# Altium schematic sheet nrf52833_qdaa_var5.SchDoc
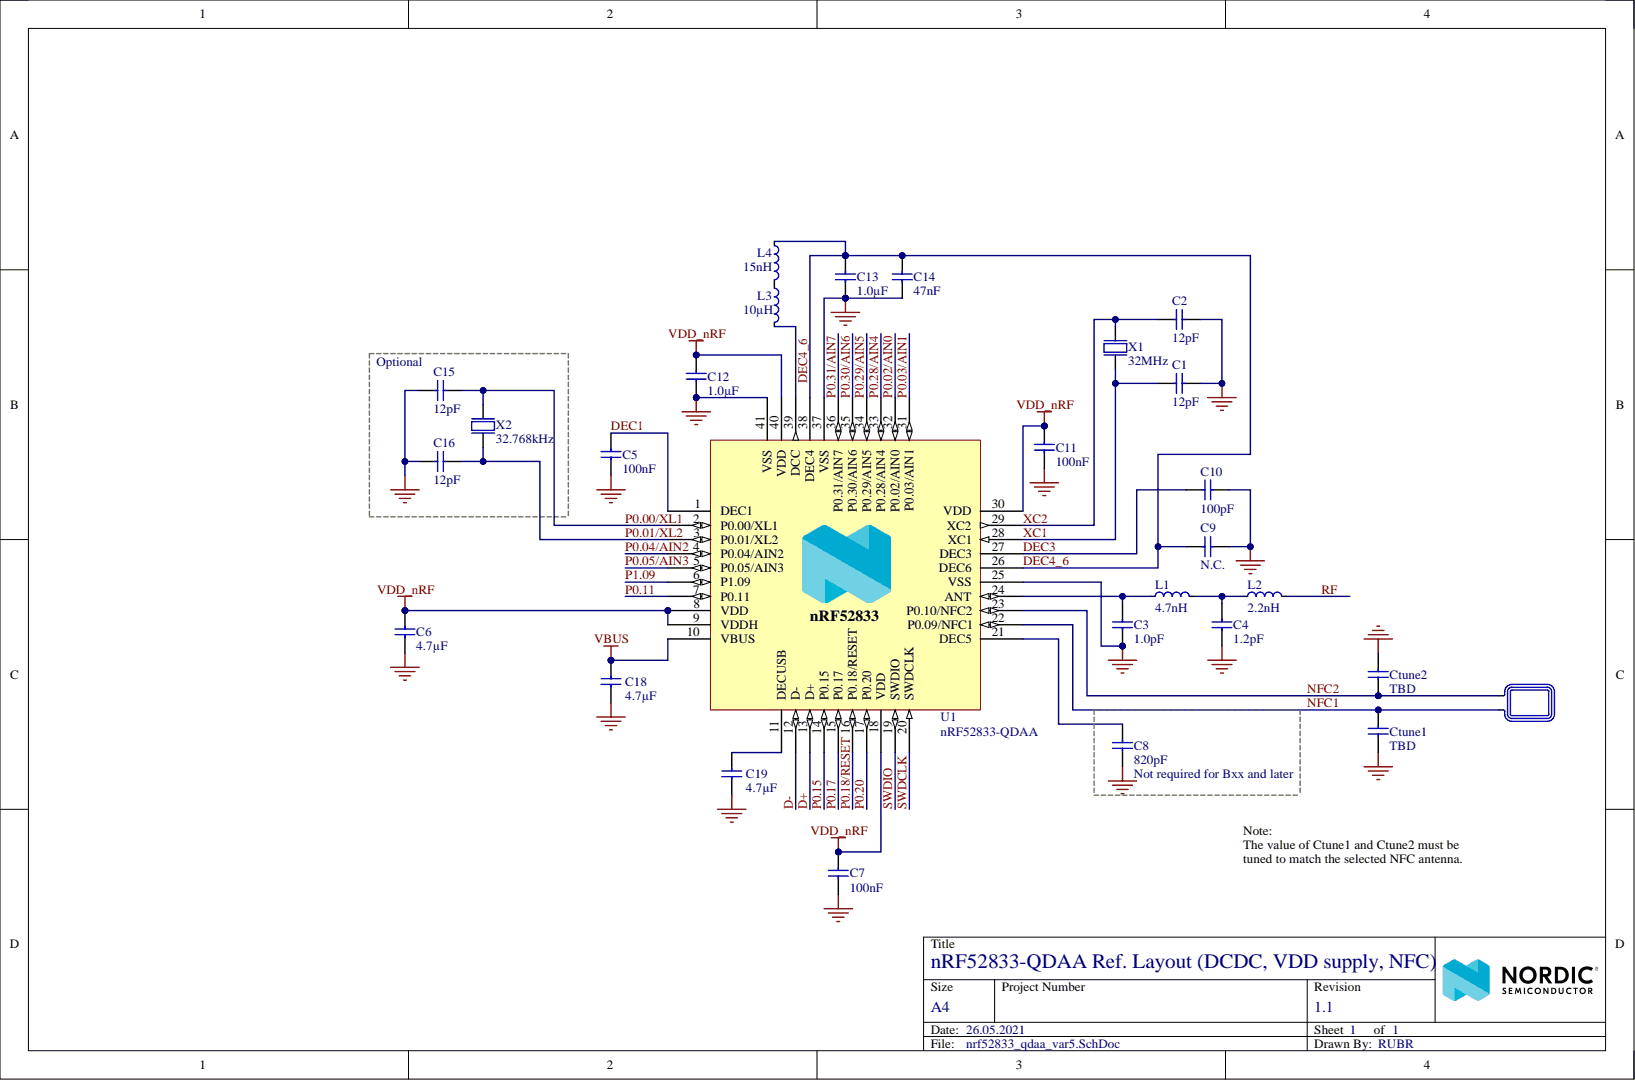
[diagram p0: nrf52833_qdaa_var5.SchDoc]

## Parts
A1  NFC Antenna  [TP_2x1mm_TH]  (value not drawn)  (no BOM)
C1  12pF  [CAPC0603X03L_C]
C2  12pF  [CAPC0603X03L_C]
C3  1.0pF  [CAPC0603X03L_C]
C4  1.2pF  [CAPC0603X03L_C]
C5  100nF  [CAPC0603X03L_C]
C6  4.7µF  [CAPC1608X06L]
C7  100nF  [CAPC0603X03L_C]
C8  820pF  [CAPC0603X03L_C]
C9  N.C.  [CAPC0603X03L_C]
C10  100pF  [CAPC0603X03L_C]
C11  100nF  [CAPC0603X03L_C]
C12  1.0µF  [CAPC1005X04L]
C13  1.0µF  [CAPC1005X04L]
C14  47nF  [CAPC0603X03L_C]
C15  12pF  [CAPC0603X03L_C]
C16  12pF  [CAPC0603X03L_C]
C18  4.7µF  [CAPC1608X06L]
C19  4.7µF  [CAPC1608X06L]
Ctune1  TBD  [CAPC0603X03L_C]
Ctune2  TBD  [CAPC0603X03L_C]
L1  4.7nH  [INDC0603X03L_C]
L2  2.2nH  [INDC0603X03L_C]
L3  10µH  [INDC1608X06L]
L4  15nH  [INDC1005X04L]
U1  nRF52833-QDAA  [QFN40P500X500X50_HS-41N]
X1  32MHz  [BT-XTAL_1612]
X2  32.768kHz  [XTAL_2012]

## Nets
GND: C1.2 C2.2 C3.1 C4.1 C5.2 C6.2 C7.2 C8.2 C9.1 C10.2 C11.2 C12.2 C13.1 C14.2 C15.2 C16.2 C18.2 C19.2 Ctune1.2 Ctune2.1 U1.25(VSS) U1.37(VSS) U1.41(VSS) X1.2 X1.4
VBUS: C18.1 U1.10(VBUS)
VDD_nRF: C6.1 C7.1 C11.1 C12.1 U1.8(VDD) U1.9(VDDH) U1.18(VDD) U1.30(VDD) U1.40(VDD)
D+: U1.13(D+)
D-: U1.12(D-)
DEC1: C5.1 U1.1(DEC1)
DEC3: C10.1 U1.27(DEC3)
DEC4_6: C9.2 C13.2 C14.1 L4.1 U1.26(DEC6) U1.38(DEC4)
NFC1: A1.2 Ctune1.1 U1.22(P0.09/NFC1)
NFC2: A1.1 Ctune2.2 U1.23(P0.10/NFC2)
P0.00/XL1: C15.1 U1.2(P0.00/XL1) X2.1
P0.01/XL2: C16.1 U1.3(P0.01/XL2) X2.2
P0.02/AIN0: U1.32(P0.02/AIN0)
P0.03/AIN1: U1.31(P0.03/AIN1)
P0.04/AIN2: U1.4(P0.04/AIN2)
P0.05/AIN3: U1.5(P0.05/AIN3)
P0.11: U1.7(P0.11)
P0.15: U1.14(P0.15)
P0.17: U1.15(P0.17)
P0.18/RESET: U1.16(P0.18/RESET)
P0.20: U1.17(P0.20)
P0.28/AIN4: U1.33(P0.28/AIN4)
P0.29/AIN5: U1.34(P0.29/AIN5)
P0.30/AIN6: U1.35(P0.30/AIN6)
P0.31/AIN7: U1.36(P0.31/AIN7)
P1.09: U1.6(P1.09)
RF: L2.2
SWDCLK: U1.20(SWDCLK)
SWDIO: U1.19(SWDIO)
XC1: C1.1 U1.28(XC1) X1.1
XC2: C2.1 U1.29(XC2) X1.3
NetC3_2: C3.2 L1.1 U1.24(ANT)
NetC4_2: C4.2 L1.2 L2.1
NetC8_1: C8.1 U1.21(DEC5)
NetC19_1: C19.1 U1.11(DECUSB)
NetL3_1: L3.1 L4.2
NetL3_2: L3.2 U1.39(DCC)

## Library data of the parts
A1 = NFC_ANT_TP: Database Table Name: Antennas$; Description: NFC Antenna; Footprint Doc: TP_2x1mm_TH; LatestRevisionDate: 2016-02-23; Library Name: Nordic Passive DB.DbLib; Part Number: NFC_ANT_TP; Pin Count: 2; Published: 2016-02-23; Value: NFC Antenna; Publisher: Nordic Semiconductor
C1 C2 C15 C16 = C0201-12p: Capacitor, NP0, ±2%; Database Table Name: Capacitors$; Component Kind: Standard; Footprint Doc: 0201; LatestRevisionDate: 2017-11-09; Library Name: Nordic Passive DB.DbLib; Part Number: C0201-12p; Pin Count: 2; Published: 2014-11-13; Value: 12pF; Publisher: Nordic Semiconductor
C3 = C0201-1.0p: Capacitor, NP0, ±5%; Database Table Name: Capacitors$; Component Kind: Standard; Footprint Doc: 0201; LatestRevisionDate: 2018-10-22; Library Name: Nordic Passive DB.DbLib; Part Number: C0201-1.0p; Pin Count: 2; Published: 2014-11-13; Value: 1.0pF; Publisher: Nordic Semiconductor
C4 = C0201-1.2p: Capacitor, NP0, ±5%; Database Table Name: Capacitors$; Component Kind: Standard; Footprint Doc: 0201; LatestRevisionDate: 2014-11-13; Library Name: Nordic Passive DB.DbLib; Part Number: C0201-1.2p; Pin Count: 2; Published: 2014-11-13; Value: 1.2pF; Publisher: Nordic Semiconductor
C5 C7 C11 = C0201-100n: Capacitor, X7S, ±10%; Database Table Name: Capacitors$; Component Kind: Standard; Footprint Doc: 0201; LatestRevisionDate: 2017-11-09; Library Name: Nordic Passive DB.DbLib; Part Number: C0201-100n; Pin Count: 2; Published: 2014-11-13; Value: 100nF; Publisher: Nordic Semiconductor
C6 C19 = C0603-4µ7-6.3V-X7R: Capacitor, X7R, ±10%; Database Table Name: Capacitors$; Component Kind: Standard; Footprint Doc: 0603; LatestRevisionDate: 2015-06-10; Library Name: Nordic Passive DB.DbLib; Part Number: C0603-4µ7-6.3V-X7R; Pin Count: 2; Published: 2015-06-10; Value: 4.7µF; Publisher: Nordic Semiconductor
C8 = C0201-820p: Capacitor, X7R, ±10%; Assembly: Not required for Bxx and later; Database Table Name: Capacitors$; Component Kind: Standard; Footprint Doc: 0201; LatestRevisionDate: 2017-11-09; Library Name: Nordic Passive DB.DbLib; Part Number: C0201-820p; Pin Count: 2; Published: 2014-11-13; Value: 820pF; Publisher: Nordic Semiconductor
C9 = C0201-N.C.: Not mounted; Assembly: Not mounted; Database Table Name: Capacitors$; Component Kind: Standard; Footprint Doc: 0201; LatestRevisionDate: 2014-11-13; Library Name: Nordic Passive DB.DbLib; Part Number: C0201-N.C.; Pin Count: 2; Published: 2014-11-13; Value: N.C.; Publisher: Nordic Semiconductor
C10 = C0201-100p: Capacitor, NP0, ±5%; Database Table Name: Capacitors$; Component Kind: Standard; Footprint Doc: 0201; LatestRevisionDate: 2017-11-09; Library Name: Nordic Passive DB.DbLib; Part Number: C0201-100p; Pin Count: 2; Published: 2014-11-13; Value: 100pF; Publisher: Nordic Semiconductor
C12 C13 = C0402-1µ0: Capacitor, X7S, ±10%; Database Table Name: Capacitors$; Component Kind: Standard; Footprint Doc: 0402; LatestRevisionDate: 2016-08-22; Library Name: Nordic Passive DB.DbLib; Part Number: C0402-1µ0; Pin Count: 2; Published: 2009-05-08; Value: 1.0µF; Publisher: Nordic Semiconductor
C14 = C0201-47n: Capacitor, X7S, ±10%; Database Table Name: Capacitors$; Component Kind: Standard; Footprint Doc: 0201; LatestRevisionDate: 2017-11-09; Library Name: Nordic Passive DB.DbLib; Part Number: C0201-47n; Pin Count: 2; Published: 2014-11-13; Value: 47nF; Publisher: Nordic Semiconductor
C18 = C0603-4µ7-10V-X7S: Capacitor, X7S, ±10%; Database Table Name: Capacitors$; Component Kind: Standard; Footprint Doc: 0603; LatestRevisionDate: 2016-08-15; Library Name: Nordic Passive DB.DbLib; Part Number: C0603-4µ7-10V-X7S; Pin Count: 2; Published: 2016-08-15; Value: 4.7µF; Publisher: Nordic Semiconductor
Ctune1 Ctune2 = C0201-TBD: Capacitor, X7R, ±10%; Database Table Name: Capacitors$; Component Kind: Standard; Footprint Doc: 0201; LatestRevisionDate: 2014-11-13; Library Name: Nordic Passive DB.DbLib; Part Number: C0201-TBD; Pin Count: 2; Published: 2014-11-13; Value: TBD; Publisher: Nordic Semiconductor
L1 = L0201-4n7: High frequency chip inductor ±5%; Database Table Name: Inductors$; Component Kind: Standard; Footprint Doc: 0201; LatestRevisionDate: 2013-04-10; Library Name: Nordic Passive DB.DbLib; Part Number: L0201-4n7; Pin Count: 2; Published: 2013-04-10; Value: 4.7nH; Publisher: Nordic Semiconductor
L2 = L0201-2n2: High frequency chip inductor ±5%; Database Table Name: Inductors$; Component Kind: Standard; Footprint Doc: 0201; LatestRevisionDate: 2013-04-10; Library Name: Nordic Passive DB.DbLib; Part Number: L0201-2n2; Pin Count: 2; Published: 2013-04-10; Value: 2.2nH; Publisher: Nordic Semiconductor
L3 = L0603-10µ-TDK: Chip inductor, IDC,min = 50 mA, ±20%; Database Table Name: Inductors$; Component Kind: Standard; Footprint Doc: 0603; LatestRevisionDate: 2012-09-19; Library Name: Nordic Passive DB.DbLib; Part Number: L0603-10µ-TDK; Pin Count: 2; Published: 2019-05-06; Value: 10µH; Publisher: Nordic Semiconductor
L4 = L0402-15n: High frequency chip inductor ±10%; Database Table Name: Inductors$; Component Kind: Standard; Footprint Doc: 0402; LatestRevisionDate: 2012-09-19; Library Name: Nordic Passive DB.DbLib; Part Number: L0402-15n; Pin Count: 2; Published: 2010-07-12; Value: 15nH; Publisher: Nordic Semiconductor
U1 = nRF52833-QDAA: Multi-protocol Bluetooth Low Energy, IEEE 802.15.4, ANT and 2.4GHz proprietary system-on-chip; Manufacturer: Nordic Semiconductor; Database Table Name: nRF Devices$; Component Kind: Standard; Footprint Doc: QFN-40; LatestRevisionDate: 2018-12-03; Library Name: Nordic Active DB.DbLib; Manufacturer Part Number: nRF52833-QDAA; Part Number: nRF52833-QDAA; Pin Count: 40; Published: 2018-12-03; Value: nRF52833-QDAA; Publisher: Nordic Semiconductor
X1 = XTAL_SMD1612_32MHz_8pF_General: XTAL SMD 1612, 32MHz, Cl=8pF, Total Tol: ±40ppm; Database Table Name: Crystal_Osc$; Component Kind: Standard; Footprint Doc: XTAL_1612; LatestRevisionDate: 2016-08-19; Library Name: Nordic Discrete DB.DbLib; Part Number: XTAL_SMD1612_32MHz_8pF_General; Pin Count: 4; Published: 2016-08-19; Value: 32MHz; Publisher: Nordic Semiconductor
X2 = XTAL_SMD2012_32.768kHz_9pF_General: XTAL SMD 2012, 32.768kHz, Cl=9pF, Total Tol: ±50ppm; Database Table Name: Crystal_Osc$; Component Kind: Standard; Footprint Doc: XTAL_2012; LatestRevisionDate: 2017-01-11; Library Name: Nordic Discrete DB.DbLib; Part Number: XTAL_SMD2012_32.768kHz_9pF_General; Pin Count: 2; Published: 2016-08-19; Value: 32.768kHz; Publisher: Nordic Semiconductor
